# Altium schematic sheet MOTOR-PANTALLA.SchDoc(MOTOR-PANTALLA)
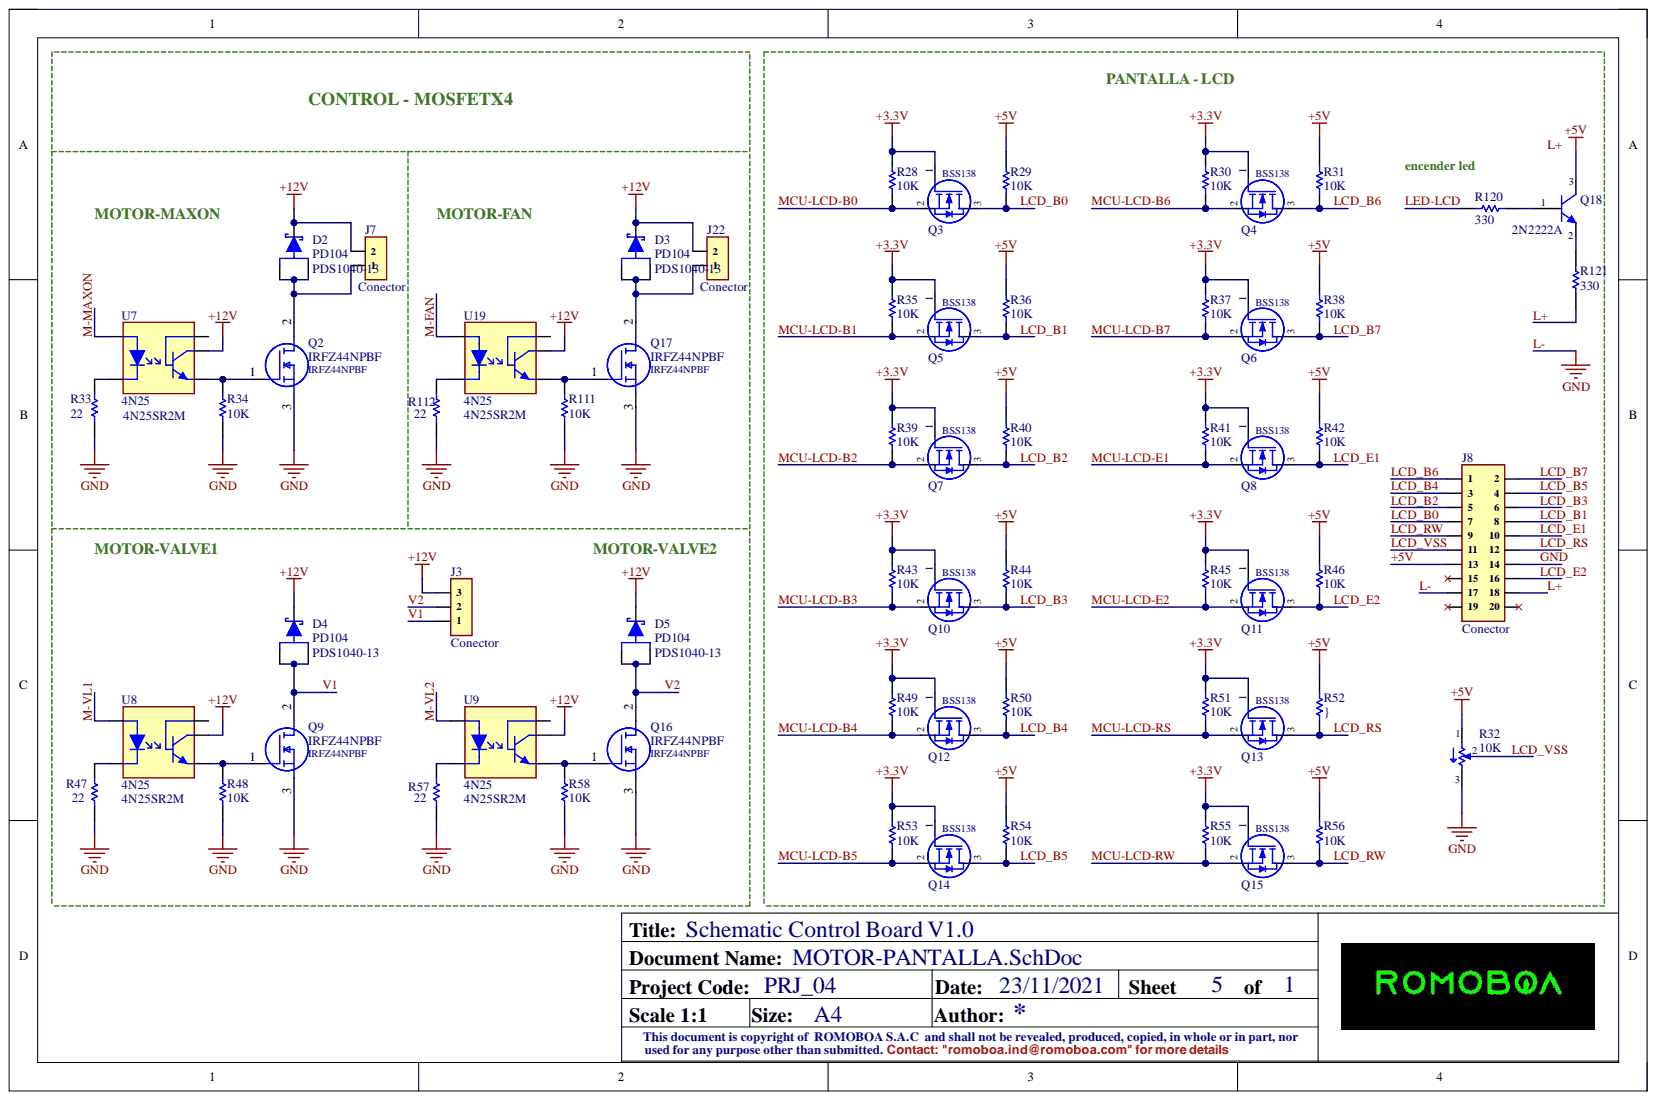
[diagram p0: MOTOR-PANTALLA.SchDoc]

## Parts
D2  PD104  Manufacturer Part Number: PDS1040-13  [POWERDI-5_L5.4-W4.0-LS6.5-RD]
D3  PD104  Manufacturer Part Number: PDS1040-13  [POWERDI-5_L5.4-W4.0-LS6.5-RD]
D4  PD104  Manufacturer Part Number: PDS1040-13  [POWERDI-5_L5.4-W4.0-LS6.5-RD]
D5  PD104  Manufacturer Part Number: PDS1040-13  [POWERDI-5_L5.4-W4.0-LS6.5-RD]
J3  Conector  [molex3x1-3.96mm]
J7  Conector  [molex2x1-3.96mm]
J8  Conector  [CON: IDC-20MS]
J22  Conector  [molex2x1-3.96mm]
Q2  IRFZ44NPBF  [TO263]
Q3  BSS138  [SOT23]
Q4  BSS138  [SOT23]
Q5  BSS138  [SOT23]
Q6  BSS138  [SOT23]
Q7  BSS138  [SOT23]
Q8  BSS138  [SOT23]
Q9  IRFZ44NPBF  [TO263]
Q10  BSS138  [SOT23]
Q11  BSS138  [SOT23]
Q12  BSS138  [SOT23]
Q13  BSS138  [SOT23]
Q14  BSS138  [SOT23]
Q15  BSS138  [SOT23]
Q16  IRFZ44NPBF  [TO263]
Q17  IRFZ44NPBF  [TO263]
Q18  2N2222A  [SOT23]
R28  10K  [RES: 0805R]
R29  10K  [RES: 0805R]
R30  10K  [RES: 0805R]
R31  10K  [RES: 0805R]
R32  10K  [POT: RES: 3296W]
R33  22  [RES: 0805R]
R34  10K  [RES: 0805R]
R35  10K  [RES: 0805R]
R36  10K  [RES: 0805R]
R37  10K  [RES: 0805R]
R38  10K  [RES: 0805R]
R39  10K  [RES: 0805R]
R40  10K  [RES: 0805R]
R41  10K  [RES: 0805R]
R42  10K  [RES: 0805R]
R43  10K  [RES: 0805R]
R44  10K  [RES: 0805R]
R45  10K  [RES: 0805R]
R46  10K  [RES: 0805R]
R47  22  [RES: 0805R]
R48  10K  [RES: 0805R]
R49  10K  [RES: 0805R]
R50  10K  [RES: 0805R]
R51  10K  [RES: 0805R]
R52  }  [RES: 0805R]
R53  10K  [RES: 0805R]
R54  10K  [RES: 0805R]
R55  10K  [RES: 0805R]
R56  10K  [RES: 0805R]
R57  22  [RES: 0805R]
R58  10K  [RES: 0805R]
R111  10K  [RES: 0805R]
R112  22  [RES: 0805R]
R120  330  [PRESICION CSR0805]
R121  330  [PRESICION CSR0805]
U7  4N25  Manufacturer Part Number: 4N25SR2M  [6-SMD-4N25]
U8  4N25  Manufacturer Part Number: 4N25SR2M  [6-SMD-4N25]
U9  4N25  Manufacturer Part Number: 4N25SR2M  [6-SMD-4N25]
U19  4N25  Manufacturer Part Number: 4N25SR2M  [6-SMD-4N25]

## Nets
+3.3V: Q3.1 Q4.1 Q5.1 Q6.1 Q7.1 Q8.1 Q10.1 Q11.1 Q12.1 Q13.1 Q14.1 Q15.1 R28.2 R30.2 R35.2 R37.2 R39.2 R41.2 R43.2 R45.2 R49.2 R51.2 R53.2 R55.2
+12V: D2.3 D3.3 D4.3 D5.3 J3.3 J7.2 J22.2 U7.5 U8.5 U9.5 U19.5
+5V: J8.13 Q18.3 R29.2 R31.2 R32.1 R36.2 R38.2 R40.2 R42.2 R44.2 R46.2 R50.2 R52.2 R54.2 R56.2
GND: J8.14 J8.17 Q2.3 Q9.3 Q16.3 Q17.3 R32.3 R33.1 R34.1 R47.1 R48.1 R57.1 R58.1 R111.1 R112.1
L+: J8.18 R121.1
LCD_B0: J8.7 Q3.3 R29.1
LCD_B1: J8.8 Q5.3 R36.1
LCD_B2: J8.5 Q7.3 R40.1
LCD_B3: J8.6 Q10.3 R44.1
LCD_B4: J8.3 Q12.3 R50.1
LCD_B5: J8.4 Q14.3 R54.1
LCD_B6: J8.1 Q4.3 R31.1
LCD_B7: J8.2 Q6.3 R38.1
LCD_E1: J8.10 Q8.3 R42.1
LCD_E2: J8.16 Q11.3 R46.1
LCD_RS: J8.12 Q13.3 R52.1
LCD_RW: J8.9 Q15.3 R56.1
LCD_VSS: J8.11 R32.2
LED-LCD: R120.2
M-FAN: U19.1
M-MAXON: U7.1
M-VL1: U8.1
M-VL2: U9.1
MCU-LCD-B0: Q3.2 R28.1
MCU-LCD-B1: Q5.2 R35.1
MCU-LCD-B2: Q7.2 R39.1
MCU-LCD-B3: Q10.2 R43.1
MCU-LCD-B4: Q12.2 R49.1
MCU-LCD-B5: Q14.2 R53.1
MCU-LCD-B6: Q4.2 R30.1
MCU-LCD-B7: Q6.2 R37.1
MCU-LCD-E1: Q8.2 R41.1
MCU-LCD-E2: Q11.2 R45.1
MCU-LCD-RS: Q13.2 R51.1
MCU-LCD-RW: Q15.2 R55.1
V1: D4.1 D4.2 J3.1 Q9.2
V2: D5.1 D5.2 J3.2 Q16.2
NetD2_1: D2.1 D2.2 J7.1 Q2.2
NetD3_1: D3.1 D3.2 J22.1 Q17.2
NetQ2_1: Q2.1 R34.2 U7.4
NetQ9_1: Q9.1 R48.2 U8.4
NetQ16_1: Q16.1 R58.2 U9.4
NetQ17_1: Q17.1 R111.2 U19.4
NetQ18_1: Q18.1 R120.1
NetQ18_2: Q18.2 R121.2
NetR33_2: R33.2 U7.2
NetR47_2: R47.2 U8.2
NetR57_2: R57.2 U9.2
NetR112_2: R112.2 U19.2

Not connected: J8 15 19 20; U7 6; U8 6; U9 6; U19 6

## Library data of the parts
D2-D5 = PDS1040L: Diode Schottky 40V 10A Automotive 3-Pin(2+Tab) PowerDI 5 T/R; Manufacturer: Diodes; Element Configuration: Common Anode; Forward Voltage: 510mV; Max Forward Surge Current (Ifsm): 275A; Max Reverse Current: 700uA; Polarity: Standard; Average Rectified Current: 10A; Category: Rectifier Diodes; Component Kind: Standard; ComponentLink1Description: New link; ComponentLink1URL: https://lcsc.com/product-detail/Schottky-Barrier-Diodes-SBD_Diodes-Incorporated-PDS1040L-13_C154714.html; ComponentLink2Description: https://datasheet.ciiva.com/25947/ds30538-25947424.pdf?src-supplier=Avnet+Europe; ComponentLink2URL: https://datasheet.ciiva.com/25947/ds30538-25947424.pdf?src-supplier=Avnet+Europe; ComponentLink3Description: https://datasheet.ciiva.com/8300/ds30538-8300993.pdf?src-supplier=Avnet; ComponentLink3URL: https://datasheet.ciiva.com/8300/ds30538-8300993.pdf?src-supplier=Avnet; ComponentLink4Description: https://datasheet.ciiva.com/4715/ds30538-79818-4715685.pdf?src-supplier=Mouser; ComponentLink4URL: https://datasheet.ciiva.com/4715/ds30538-79818-4715685.pdf?src-supplier=Mouser; ComponentLink5Description: https://datasheet.ciiva.com/34302/1541335-34302410.pdf?src-supplier=Jameco; ComponentLink5URL: https://datasheet.ciiva.com/34302/1541335-34302410.pdf?src-supplier=Jameco; ComponentLink6Description: https://datasheet.ciiva.com/915/ds30538-915075.pdf?src-supplier=Digi-Key; ComponentLink6URL: https://datasheet.ciiva.com/915/ds30538-915075.pdf?src-supplier=Digi-Key; ComponentLink7Description: https://datasheet.ciiva.com/915/ds30538-915076.pdf?src-supplier=Digi-Key; ComponentLink7URL: https://datasheet.ciiva.com/915/ds30538-915076.pdf?src-supplier=Digi-Key; ComponentLink8Description: https://datasheet.ciiva.com/915/ds30538-915074.pdf?src-supplier=Digi-Key; ComponentLink8URL: https://datasheet.ciiva.com/915/ds30538-915074.pdf?src-supplier=Digi-Key; ComponentLink9Description: https://datasheet.ciiva.com/7964/ds30538-7964283.pdf?src-supplier=Element14; ComponentLink9URL: https://datasheet.ciiva.com/7964/ds30538-7964283.pdf?src-supplier=Element14; ComponentLink10Description: https://datasheet.ciiva.com/16215/ds30538-16215597.pdf?src-supplier=Future+Electronics; ComponentLink10URL: https://datasheet.ciiva.com/16215/ds30538-16215597.pdf?src-supplier=Future+Electronics; Contact Plating: Tin,Matte; Current Rating: 10A; Forward Current: 10A; Height: 1.15mm; Lead Free: Lead Free; Length: 4.05mm; Library Name: DIODE.IntLib; Max Operating Temperature: 180°C; Max Repetitive Reverse Voltage (Vrrm): 40V; Max Reverse Voltage (DC): 40V; Min Operating Temperature: -65°C; Mount: Surface Mount; Number of Pins: 5; Output Current: 10A; Package Quantity: 5000; Packaging: Tape and Reel; Peak Non-Repetitive Surge Current: 275A; Pricing 1: 1=0.56980, 10=0.46130, 30=0.44140, 100=0.42160, 500=0.41270, 1000=0.40840, 1=0.54090, 10=0.43800, 30=0.41910, 100=0.40030, 500=0.39180, 1000=0.38770, 1=2.76290, 10=2.23710, 30=2.14040, 100=2.04440, 500=2.00130, 1000=1.98040, 1=2.59720, 10=2.10290, 30=2.01200, 100=1.92180, 500=1.88130, 1000=1.86160, 1=0.34890, 10=0.28250, 30=0.27030, 100=0.25820, 500=0.25270, 1000=0.25010, 1=0.31470, 10=0.25480, 30=0.24380, 100=0.23290, 500=0.22800, 1000=0.22560, 1=3.27840, 10=2.65450, 30=2.53980, 100=2.42590, 500=2.37470, 1000=2.34990, 1=44.05950, 10=35.67460, 30=34.13310, 100=32.60190, 500=31.91450, 1000=31.58120, 1=1.71750, 10=1.39070, 30=1.33060, 100=1.27090, 500=1.24410, 1000=1.23110, 1=31.35360, 10=25.38680, 30=24.28980, 100=23.20020, 500=22.71100, 1000=22.47380, 1=3.57710, 10=2.89630, 30=2.77120, 100=2.64690, 500=2.59110, 1000=2.56400, 1=0.56460, 10=0.45720, 30=0.43740, 100=0.41780, 500=0.40900, 1000=0.40470, 1=0.42300, 10=0.34250, 30=0.32770, 100=0.31300, 500=0.30640, 1000=0.30320 (AUD); Radiation Hardening: No; REACH SVHC: No SVHC; RoHS: Yes; Stock 1: 9266; Supplier 1: LCSC; Supplier Part Number 1: C110512; Termination: SMD/SMT; Voltage Rating (DC): 40V; Weight: 0.003386oz; Width: 5.45mm
J3 = HEADER 1X3: HEADER THT 2.54MM; Component Kind: Standard; Library Name: CONECTORES-HEADER.IntLib
J7 J22 = HEADER 1X2: HEADER  THT 2.54MM; Component Kind: Standard; Library Name: CONECTORES-HEADER.IntLib
J8 = HEADER 2X10: HEADER THT 2.54MM; Component Kind: Standard; Library Name: CONECTORES-HEADER.IntLib
Q2 Q9 Q16 Q17 = IRFZ44NPBF: N-channel MOSFET Transistor, 49 A, 55 V, 3-Pin D2PAK; Manufacturer: International Rectifier; Continuous Drain Current (ID): 49A; Drain to Source Breakdown Voltage: 55V; Drain to Source Resistance: 17.5mR; Element Configuration: Single; Fall Time: 45ns; Gate to Source Voltage (Vgs): 20V; Input Capacitance: 1.47nF; Max Power Dissipation: 94W; Nominal Vgs: 2.1V; Number of Elements: 1; Recovery Time: 95ns; Rise Time: 60ns; Turn-Off Delay Time: 44ns; Turn-On Delay Time: 12ns; Case/Package: TO-220AB; Category: MOSFETs; Component Kind: Standard; ComponentLink1Description: https://datasheet.ciiva.com/939/irfz44npbf-939122.pdf?src-supplier=Digi-Key; ComponentLink1URL: https://datasheet.ciiva.com/939/irfz44npbf-939122.pdf?src-supplier=Digi-Key; ComponentLink2Description: https://datasheet.ciiva.com/26791/irfz44npbf-26791355.pdf?src-supplier=IIIC; ComponentLink2URL: https://datasheet.ciiva.com/26791/irfz44npbf-26791355.pdf?src-supplier=IIIC; ComponentLink3Description: https://datasheet.ciiva.com/29326/162747-da-01-en-irfz-44-n-29326623.pdf?src-supplier=Conrad; ComponentLink3URL: https://datasheet.ciiva.com/29326/162747-da-01-en-irfz-44-n-29326623.pdf?src-supplier=Conrad; ComponentLink4Description: https://datasheet.ciiva.com/8079/irfz44n-8079627.pdf?src-supplier=Element14; ComponentLink4URL: https://datasheet.ciiva.com/8079/irfz44n-8079627.pdf?src-supplier=Element14; ComponentLink5Description: https://datasheet.ciiva.com/16199/irfz44npbf-16199655.pdf?src-supplier=Future+Electronics; ComponentLink5URL: https://datasheet.ciiva.com/16199/irfz44npbf-16199655.pdf?src-supplier=Future+Electronics; ComponentLink6Description: https://datasheet.ciiva.com/7835/irfz44npbf-7835740.pdf?src-supplier=Element14; ComponentLink6URL: https://datasheet.ciiva.com/7835/irfz44npbf-7835740.pdf?src-supplier=Element14; ComponentLink7Description: https://datasheet.ciiva.com/948/irfz44nspbf-948320.pdf?src-supplier=Digi-Key; ComponentLink7URL: https://datasheet.ciiva.com/948/irfz44nspbf-948320.pdf?src-supplier=Digi-Key; ComponentLink8Description: https://datasheet.ciiva.com/14170/iuirfz44ns-data-e-14170666.pdf?src-supplier=Distrelec; ComponentLink8URL: https://datasheet.ciiva.com/14170/iuirfz44ns-data-e-14170666.pdf?src-supplier=Distrelec; ComponentLink9Description: https://datasheet.ciiva.com/15137/getdatasheetpartid-76356-15137408.pdf?src-supplier=Verical; ComponentLink9URL: https://datasheet.ciiva.com/15137/getdatasheetpartid-76356-15137408.pdf?src-supplier=Verical; ComponentLink10Description: https://datasheet.ciiva.com/26791/irfz44nspbf-26791356.pdf?src-supplier=IIIC; ComponentLink10URL: https://datasheet.ciiva.com/26791/irfz44nspbf-26791356.pdf?src-supplier=IIIC; ComponentLink11Description: https://datasheet.ciiva.com/14554/70017056-14554485.pdf?src-supplier=Allied+Electronics; ComponentLink11URL: https://datasheet.ciiva.com/14554/70017056-14554485.pdf?src-supplier=Allied+Electronics; ComponentLink12Description: https://datasheet.ciiva.com/7835/irfz44nspbf-7835741.pdf?src-supplier=Element14; ComponentLink12URL: https://datasheet.ciiva.com/7835/irfz44nspbf-7835741.pdf?src-supplier=Element14; Current Rating: 49A; Drain to Source Voltage (Vdss): 55V; Dual Supply Voltage: 55V; Height: 8.77mm; Lead Free: Lead Free; Lead Pitch: 2.54mm; Length: 10.67mm; Library Name: TRANSISTORES.IntLib; Manufacturer Part Number: IRFZ44NPBF; Max Operating Temperature: 175°C; Min Operating Temperature: -55°C; Mount: Through Hole; Number of Pins: 3; On-State Resistance: 17.5MR; Package Quantity: 50; Packaging: Rail/Tube; Power Dissipation: 94W; Pricing 1: 1=0.47590, 10=0.35290, 30=0.32970, 100=0.30640, 500=0.29720, 1000=0.29250, 1=0.45060, 10=0.33410, 30=0.31210, 100=0.29010, 500=0.28130, 1000=0.27690, 1=2.29720, 10=1.70330, 30=1.59120, 100=1.47910, 500=1.43430, 1000=1.41190, 1=2.16000, 10=1.60150, 30=1.49620, 100=1.39080, 500=1.34860, 1000=1.32760, 1=0.29020, 10=0.21520, 30=0.20100, 100=0.18690, 500=0.18120, 1000=0.17840, 1=0.26380, 10=0.19560, 30=0.18270, 100=0.16990, 500=0.16470, 1000=0.16210, 1=2.64820, 10=1.96350, 30=1.83440, 100=1.70520, 500=1.65350, 1000=1.62770, 1=36.17060, 10=26.81910, 30=25.05470, 100=23.29030, 500=22.58450, 1000=22.23160, 1=1.41840, 10=1.05170, 30=0.98250, 100=0.91330, 500=0.88570, 1000=0.87180, 1=26.43110, 10=19.59770, 30=18.30840, 100=17.01900, 500=16.50330, 1000=16.24540, 1=3.02450, 10=2.24250, 30=2.09500, 100=1.94750, 500=1.88840, 1000=1.85890, 1=0.46360, 10=0.34370, 30=0.32110, 100=0.29850, 500=0.28950, 1000=0.28490, 1=0.34167, 10=0.25333, 30=0.23667, 100=0.22000, 500=0.21333, 1000=0.21000 (AUD); Pricing 2: 1=1.54850, 10=1.17940, 30=1.11200, 100=1.04470, 500=1.01450, 1000=1.00060, 1=1.46600, 10=1.11660, 30=1.05280, 100=0.98910, 500=0.96050, 1000=0.94730, 1=7.47420, 10=5.69250, 30=5.36750, 100=5.04260, 500=4.89690, 1000=4.82960, 1=7.02770, 10=5.35250, 30=5.04690, 100=4.74140, 500=4.60440, 1000=4.54120, 1=0.94420, 10=0.71920, 30=0.67810, 100=0.63710, 500=0.61860, 1000=0.61020, 1=0.85830, 10=0.65370, 30=0.61640, 100=0.57900, 500=0.56230, 1000=0.55460, 1=8.61630, 10=6.56230, 30=6.18770, 100=5.81310, 500=5.64520, 1000=5.56770, 1=117.68660, 10=89.63240, 30=84.51550, 100=79.39870, 500=77.10490, 1000=76.04630, 1=4.61510, 10=3.51490, 30=3.31430, 100=3.11360, 500=3.02370, 1000=2.98220, 1=85.99780, 10=65.49750, 30=61.75850, 100=58.01950, 500=56.34330, 1000=55.56970, 1=9.84060, 10=7.49480, 30=7.06690, 100=6.63910, 500=6.44730, 1000=6.35870, 1=1.50840, 10=1.14880, 30=1.08320, 100=1.01760, 500=0.98820, 1000=0.97470, 1=1.11167, 10=0.84667, 30=0.79833, 100=0.75000, 500=0.72833, 1000=0.71833 (AUD); Radiation Hardening: No; Rds On Max: 17.5mR; REACH SVHC: No SVHC; RoHS: Yes; Stock 1: 5864; Stock 2: 2353; Supplier 1: LCSC; Supplier 2: LCSC; Supplier Part Number 1: C2586; Supplier Part Number 2: C58228; Termination: Through Hole; Threshold Voltage: 2.1V; Voltage Rating (DC): 55V; Width: 4.83mm
Q3-Q8 Q10-Q15 = BSS138: Trans MOSFET N-CH 50V 0.22A 3-Pin SOT-23 T/R; Manufacturer: ON Semiconductor / Fairchild; Continuous Drain Current (ID): 220mA; Drain to Source Resistance: 3.5R; Element Configuration: Single; Fall Time: 9ns; Gate to Source Voltage (Vgs): 20V; Input Capacitance: 27pF; Max Power Dissipation: 360mW; Nominal Vgs: 1.3V; Number of Elements: 1; On-State Resistance: 6R; Turn-Off Delay Time: 20ns; Turn-On Delay Time: 2.5ns; Case/Package: SOT-23; Category: MOSFETs; Component Kind: Standard; ComponentLink1Description: https://datasheet.ciiva.com/931/bss138-931776.pdf?src-supplier=Digi-Key; ComponentLink1URL: https://datasheet.ciiva.com/931/bss138-931776.pdf?src-supplier=Digi-Key; ComponentLink2Description: https://datasheet.ciiva.com/1190/193736-1190130.pdf?src-supplier=Element14; ComponentLink2URL: https://datasheet.ciiva.com/1190/193736-1190130.pdf?src-supplier=Element14; ComponentLink3Description: https://datasheet.ciiva.com/3304/bss138-3304424.pdf?src-supplier=Avnet; ComponentLink3URL: https://datasheet.ciiva.com/3304/bss138-3304424.pdf?src-supplier=Avnet; ComponentLink4Description: https://datasheet.ciiva.com/27050/784896-27050043.pdf?src-supplier=Jameco; ComponentLink4URL: https://datasheet.ciiva.com/27050/784896-27050043.pdf?src-supplier=Jameco; ComponentLink5Description: https://datasheet.ciiva.com/1190/193736-1190131.pdf?src-supplier=Element14; ComponentLink5URL: https://datasheet.ciiva.com/1190/193736-1190131.pdf?src-supplier=Element14; ComponentLink6Description: https://datasheet.ciiva.com/1254/193736-1254983.pdf?src-supplier=Newark; ComponentLink6URL: https://datasheet.ciiva.com/1254/193736-1254983.pdf?src-supplier=Newark; ComponentLink7Description: https://datasheet.ciiva.com/22655/193736-22655240.pdf?src-supplier=Element14; ComponentLink7URL: https://datasheet.ciiva.com/22655/193736-22655240.pdf?src-supplier=Element14; ComponentLink8Description: https://datasheet.ciiva.com/16834/bss138-16834843.pdf?src-supplier=Future+Electronics; ComponentLink8URL: https://datasheet.ciiva.com/16834/bss138-16834843.pdf?src-supplier=Future+Electronics; ComponentLink9Description: https://datasheet.ciiva.com/26229/bss138-26229007.pdf?src-supplier=Avnet+Europe; ComponentLink9URL: https://datasheet.ciiva.com/26229/bss138-26229007.pdf?src-supplier=Avnet+Europe; Contact Plating: Tin,Matte; Current Rating: 220mA; Drain to Source Breakdown Voltage: 50V; Drain to Source Voltage (Vdss): 50V; Dual Supply Voltage: 50V; Height: 0.93mm; Lead Free: Lead Free; Length: 2.92mm; Library Name: TRANSISTORES.IntLib; Manufacturer Part Number: BSS138; Max Operating Temperature: 150°C; Min Operating Temperature: -55°C; Mount: Surface Mount; Number of Pins: 3; Package Quantity: 1; Packaging: Tape and Reel; Power Dissipation: 360mW; Pricing 1: 10=0.05890, 100=0.04470, 300=0.04210, 1000=0.03950, 5000=0.03830, 10000=0.03770, 10=0.05540, 100=0.04200, 300=0.03960, 1000=0.03710, 5000=0.03610, 10000=0.03550, 10=0.28240, 100=0.21430, 300=0.20180, 1000=0.18930, 5000=0.18380, 10000=0.18100, 10=0.26490, 100=0.20100, 300=0.18930, 1000=0.17760, 5000=0.17240, 10000=0.16980, 10=0.03560, 100=0.02700, 300=0.02540, 1000=0.02390, 5000=0.02320, 10000=0.02280, 10=0.03250, 100=0.02460, 300=0.02320, 1000=0.02180, 5000=0.02110, 10000=0.02080, 10=0.32070, 100=0.24340, 300=0.22920, 1000=0.21500, 5000=0.20870, 10000=0.20560, 10=4.36970, 100=3.31640, 300=3.12300, 1000=2.92950, 5000=2.84360, 10000=2.80100, 10=0.17260, 100=0.13100, 300=0.12340, 1000=0.11570, 5000=0.11230, 10000=0.11070, 10=3.23400, 100=2.45440, 300=2.31130, 1000=2.16810, 5000=2.10450, 10000=2.07300, 10=0.37800, 100=0.28690, 300=0.27020, 1000=0.25340, 5000=0.24600, 10000=0.24230, 10=0.05700, 100=0.04330, 300=0.04080, 1000=0.03820, 5000=0.03710, 10000=0.03660, 10=0.04138, 100=0.03141, 300=0.02958, 1000=0.02774, 5000=0.02693, 10000=0.02653 (AUD); Radiation Hardening: No; Rds On Max: 3.5R; REACH SVHC: No SVHC; Rise Time: 9ns; RoHS: Yes; Stock 1: 36340; Supplier 1: LCSC; Supplier Part Number 1: C52895; Termination: SMD/SMT; Threshold Voltage: 1.3V; Voltage Rating (DC): 50V; Weight: 0.03g; Width: 1.3mm
Q18 = MMBT2222A-SMD: Trans  BJT NPN 40V 0.6A; Manufacturer: Diodes; Collector Base Voltage (VCBO): 75V; Collector Emitter Saturation Voltage: 1V; Continuous Collector Current: 600mA; Current: 1A; Element Configuration: Single; Emitter Base Voltage (VEBO): 6V; Frequency: 300MHz; hFE Min: 100; Max Power Dissipation: 300mW; Number of Elements: 1; Power Dissipation: 350mW; Case/Package: SOT-23; Category: BJTs; Collector Emitter Breakdown Voltage: 40V; Collector Emitter Voltage (VCEO): 40V; Component Kind: Standard; ComponentLink1Description: https://datasheet.ciiva.com/15139/getdatasheetpartid-82692-15139078.pdf?src-supplier=Verical; ComponentLink1URL: https://datasheet.ciiva.com/15139/getdatasheetpartid-82692-15139078.pdf?src-supplier=Verical; ComponentLink2Description: https://datasheet.ciiva.com/11198/ds30041-11198965.pdf?src-supplier=Avnet; ComponentLink2URL: https://datasheet.ciiva.com/11198/ds30041-11198965.pdf?src-supplier=Avnet; ComponentLink3Description: https://datasheet.ciiva.com/16199/ds30041-16199045.pdf?src-supplier=Future+Electronics; ComponentLink3URL: https://datasheet.ciiva.com/16199/ds30041-16199045.pdf?src-supplier=Future+Electronics; ComponentLink4Description: https://datasheet.ciiva.com/972/ds30041-972550.pdf?src-supplier=Digi-Key; ComponentLink4URL: https://datasheet.ciiva.com/972/ds30041-972550.pdf?src-supplier=Digi-Key; ComponentLink5Description: https://datasheet.ciiva.com/972/ds30041-972551.pdf?src-supplier=Digi-Key; ComponentLink5URL: https://datasheet.ciiva.com/972/ds30041-972551.pdf?src-supplier=Digi-Key; ComponentLink6Description: https://datasheet.ciiva.com/972/ds30041-972552.pdf?src-supplier=Digi-Key; ComponentLink6URL: https://datasheet.ciiva.com/972/ds30041-972552.pdf?src-supplier=Digi-Key; ComponentLink7Description: https://datasheet.ciiva.com/11201/ds30041-11201864.pdf?src-supplier=Avnet; ComponentLink7URL: https://datasheet.ciiva.com/11201/ds30041-11201864.pdf?src-supplier=Avnet; ComponentLink8Description: https://datasheet.ciiva.com/16615/ds30041-16615211.pdf?src-supplier=Avnet+Europe; ComponentLink8URL: https://datasheet.ciiva.com/16615/ds30041-16615211.pdf?src-supplier=Avnet+Europe; ComponentLink9Description: https://datasheet.ciiva.com/8029/ds30041-8029709.pdf?src-supplier=Element14; ComponentLink9URL: https://datasheet.ciiva.com/8029/ds30041-8029709.pdf?src-supplier=Element14; Contact Plating: Tin,Matte; Current Rating: 600mA; Gain Bandwidth Product: 300MHz; Height: 1mm; Lead Free: Lead Free; Length: 3.05mm; Library Name: TRANSISTORES.IntLib; Manufacturer Part Number: MMBT2222A; Max Breakdown Voltage: 40V; Max Collector Current: 600mA; Max Frequency: 300MHz; Max Operating Temperature: 150°C; Min Operating Temperature: -55°C; Mount: Surface Mount; Number of Pins: 3; Package Quantity: 3000; Packaging: Tape and Reel; Polarity: NPN; Pricing 1: 20=0.02430, 200=0.01800, 600=0.01690, 2000=0.01570, 10000=0.01520, 20000=0.01500, 20=0.02280, 200=0.01700, 600=0.01590, 2000=0.01480, 10000=0.01430, 20000=0.01410, 20=0.11640, 200=0.08640, 600=0.08100, 2000=0.07550, 10000=0.07300, 20000=0.07180, 20=0.10910, 200=0.08110, 600=0.07590, 2000=0.07080, 10000=0.06850, 20000=0.06740, 20=0.01470, 200=0.01090, 600=0.01020, 2000=0.00950, 10000=0.00920, 20000=0.00900, 20=0.01340, 200=0.00990, 600=0.00930, 2000=0.00870, 10000=0.00840, 20000=0.00830, 20=0.13210, 200=0.09820, 600=0.09190, 2000=0.08570, 10000=0.08290, 20000=0.08160, 20=1.80040, 200=1.33760, 600=1.25260, 2000=1.16760, 10000=1.12980, 20000=1.11110, 20=0.07110, 200=0.05280, 600=0.04950, 2000=0.04610, 10000=0.04460, 20000=0.04390, 20=1.33250, 200=0.99000, 600=0.92710, 2000=0.86410, 10000=0.83620, 20000=0.82230, 20=0.15580, 200=0.11570, 600=0.10840, 2000=0.10100, 10000=0.09770, 20000=0.09610, 20=0.02350, 200=0.01750, 600=0.01630, 2000=0.01520, 10000=0.01470, 20000=0.01450, 20=0.01705, 200=0.01267, 600=0.01186, 2000=0.01106, 10000=0.01070, 20000=0.01052 (AUD); Radiation Hardening: No; REACH SVHC: No SVHC; RoHS: Yes; Stock 1: 6340; Supplier 1: LCSC; Supplier Part Number 1: C94515; Termination: SMD/SMT; Transition Frequency: 300MHz; Voltage: 40V; Voltage Rating (DC): 40V; Weight: 0.000282oz; Width: 1.4mm
R28-R31 R33-R58 R111 R112 R120 R121 = Res1-SMD: Component Kind: Standard; Library Name: RESISTOR-POT..IntLib
R32 = POTENCIOMETRO2: RESISTOR VARIABLE; Component Kind: Standard; Library Name: RESISTOR-POT..IntLib
U7-U9 U19 = 4N25: OPTOISO 4.17KV TRANS W/BASE 6SMD; Manufacturer: ON Semiconductor; Collector Emitter Voltage (VCEO): 500mV; Forward Voltage: 1.18V; Max Output Voltage: 30V; Number of Channels: 1; Case/Package: SMD/SMT; Category: Optocouplers; Component Kind: Standard; ComponentLink1Description: http://www.farnell.com/datasheets/2287881.pdf?src-supplier=Farnell; ComponentLink1URL: http://www.farnell.com/datasheets/2287881.pdf?src-supplier=Farnell; ComponentLink2Description: https://produktinfo.conrad.com/datenblaetter/1200000-1299999/001265459-da-01-en-OPTOISOLATOR_TRANS_7__4N25SR2M_SMD_6_ONS.PDF?src-supplier=Conrad; ComponentLink2URL: https://produktinfo.conrad.com/datenblaetter/1200000-1299999/001265459-da-01-en-OPTOISOLATOR_TRANS_7__4N25SR2M_SMD_6_ONS.PDF?src-supplier=Conrad; ComponentLink3Description: http://www.onsemi.com/pub/Collateral/4N37M-D.pdf?src-supplier=Digi-Key; ComponentLink3URL: http://www.onsemi.com/pub/Collateral/4N37M-D.pdf?src-supplier=Digi-Key; ComponentLink4Description: http://www.farnell.com/datasheets/2287881.pdf?src-supplier=Newark; ComponentLink4URL: http://www.farnell.com/datasheets/2287881.pdf?src-supplier=Newark; ComponentLink5Description: https://www.fairchildsemi.com/datasheets/4N/4N25M.pdf?src-supplier=Digi-Key; ComponentLink5URL: https://www.fairchildsemi.com/datasheets/4N/4N25M.pdf?src-supplier=Digi-Key; Library Name: RELAY-OPTOCOUPLER.IntLib; Max Operating Temperature: 100°C; Min Operating Temperature: -40°C; Mount: Surface Mount; Packaging: Cut Tape; Pricing 1: 1=0.51250, 10=0.38900, 30=0.36610, 100=0.34320, 500=0.33410, 1000=0.32950, 1=0.49200, 10=0.37340, 30=0.35140, 100=0.32950, 500=0.32070, 1000=0.31630, 1=2.55150, 10=1.93640, 30=1.82250, 100=1.70860, 500=1.66300, 1000=1.64030, 1=2.34450, 10=1.77930, 30=1.67470, 100=1.57000, 500=1.52810, 1000=1.50720, 1=0.31510, 10=0.23920, 30=0.22510, 100=0.21100, 500=0.20540, 1000=0.20260, 1=0.29180, 10=0.22140, 30=0.20840, 100=0.19540, 500=0.19020, 1000=0.18760, 1=2.89340, 10=2.19590, 30=2.06670, 100=1.93760, 500=1.88590, 1000=1.86010, 1=39.63120, 10=30.07720, 30=28.30800, 100=26.53880, 500=25.83100, 1000=25.47720, 1=1.55060, 10=1.17680, 30=1.10760, 100=1.03840, 500=1.01070, 1000=0.99680, 1=27.96080, 10=21.22020, 30=19.97200, 100=18.72380, 500=18.22440, 1000=17.97480, 1=3.27170, 10=2.48300, 30=2.33690, 100=2.19090, 500=2.13240, 1000=2.10320, 1=0.51070, 10=0.38760, 30=0.36480, 100=0.34200, 500=0.33290, 1000=0.32830, 1=0.37333, 10=0.28333, 30=0.26667, 100=0.25000, 500=0.24333, 1000=0.24000 (AUD); RoHS: No; Stock 1: 4983; Supplier 1: LCSC; Supplier Part Number 1: C44165
